# Altium schematic sheet MCU.SchDoc
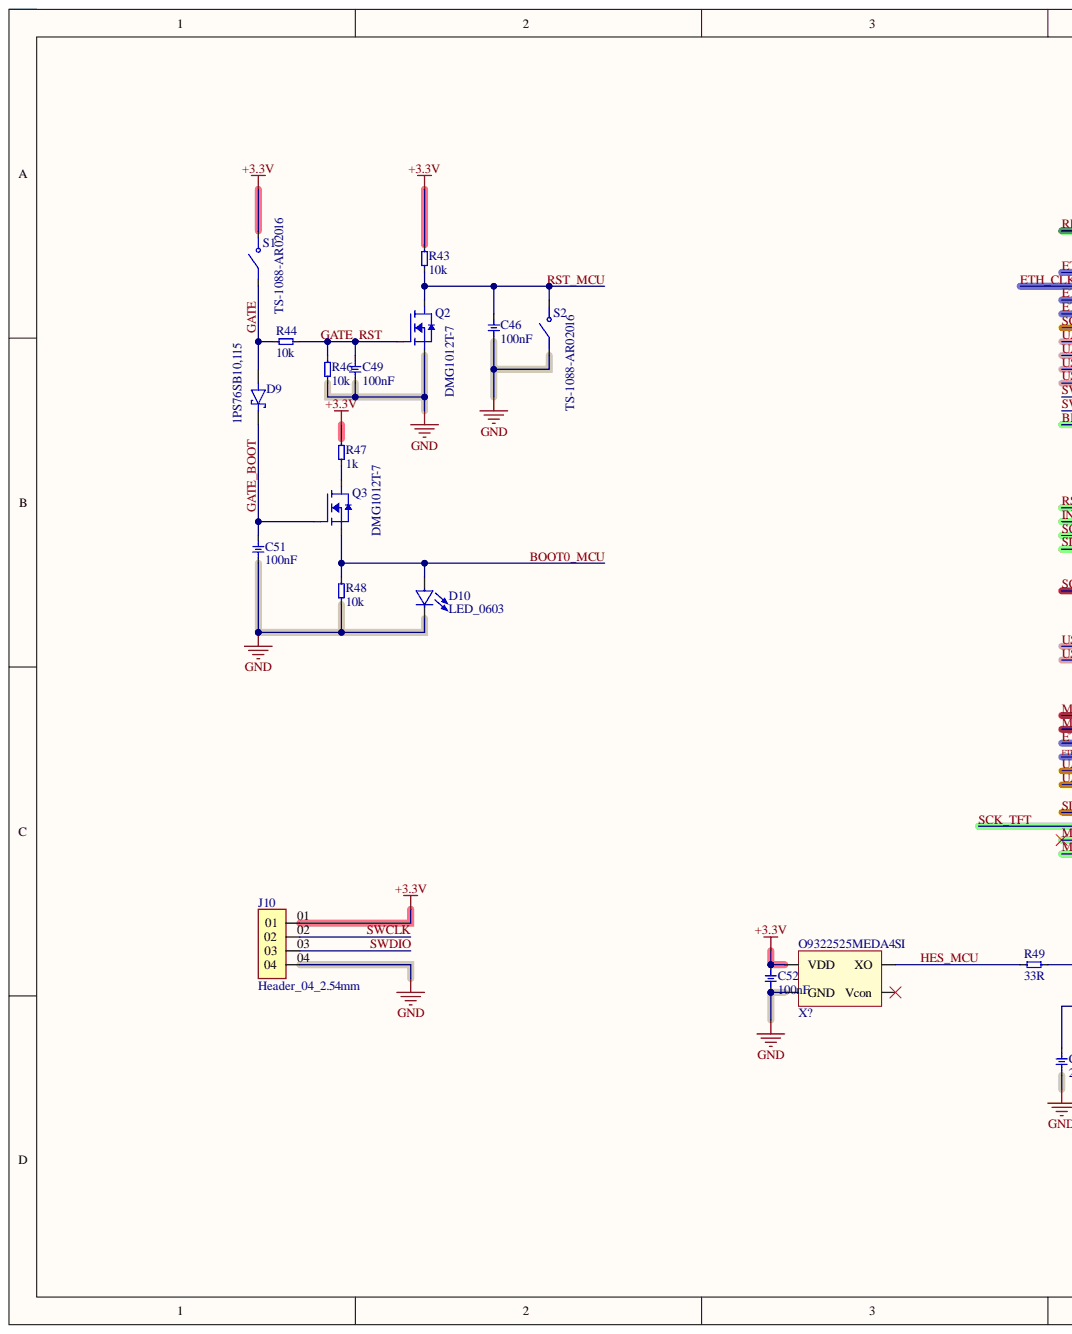
[diagram p0: MCU.SchDoc, left]
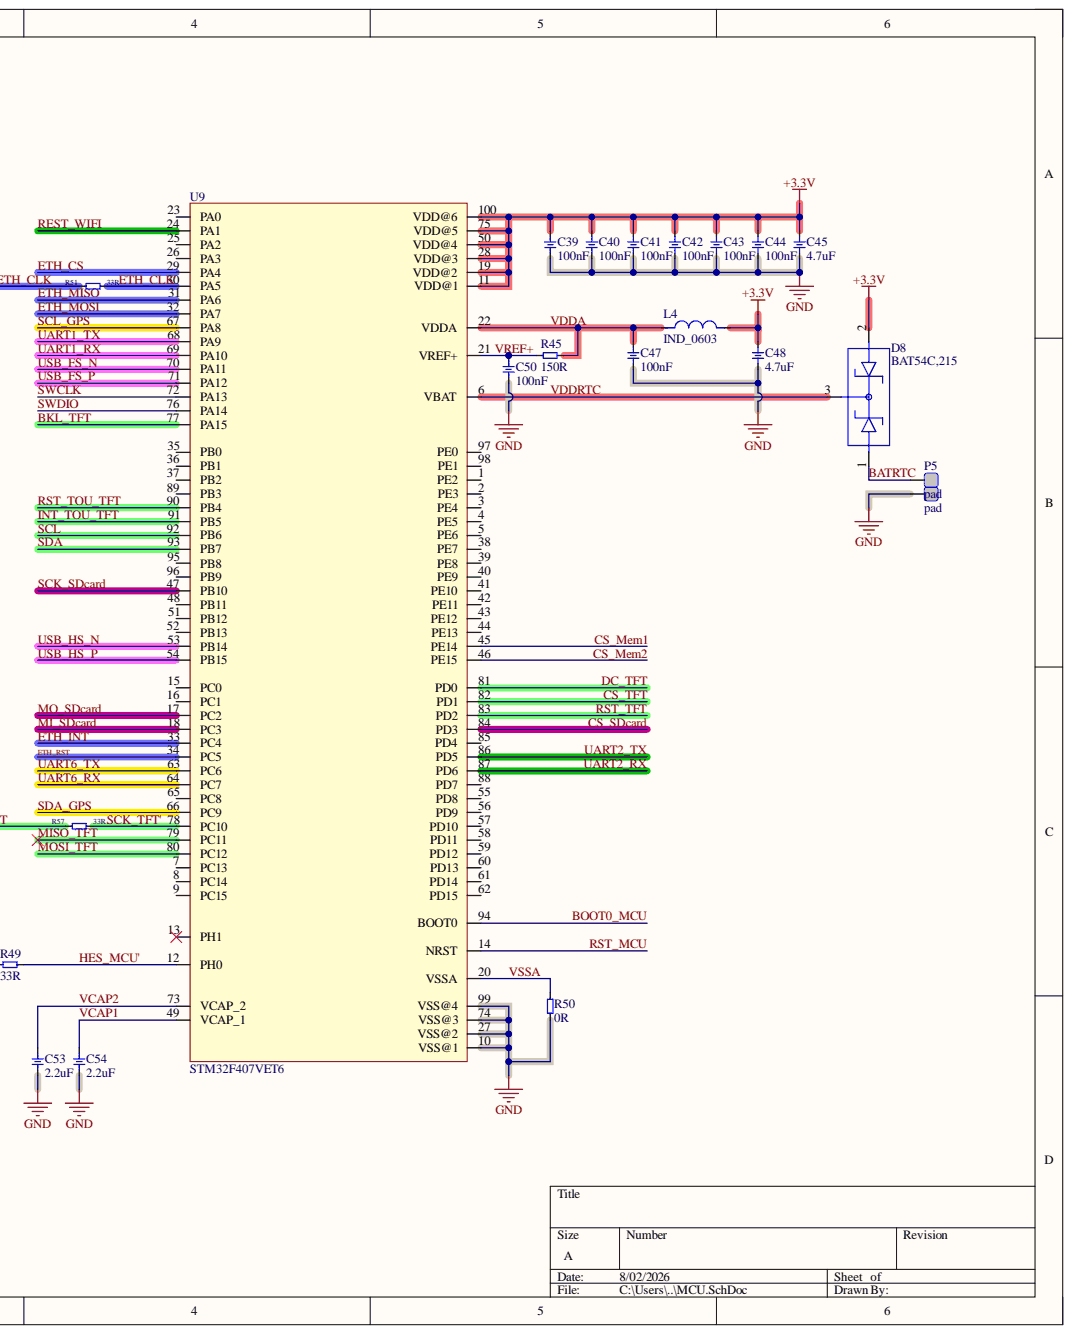
[diagram p1: MCU.SchDoc, right]

## Parts
C39  100nF  [CAP_0603]
C40  100nF  [CAP_0603]
C41  100nF  [CAP_0603]
C42  100nF  [CAP_0603]
C43  100nF  [CAP_0603]
C44  100nF  [CAP_0603]
C45  4.7uF  [CAP_0603]
C46  100nF  [CAP_0402]
C47  100nF  [CAP_0603]
C48  4.7uF  [CAP_0603]
C49  100nF  [CAP_0402]
C50  100nF  [CAP_0603]
C51  100nF  [CAP_0402]
C52  100nF  [CAP_0603]
C53  2.2uF  [CAP_0603]
C54  2.2uF  [CAP_0603]
D8  BAT54C,215  [SOT23]
D9  1PS76SB10,115  [SOD323]
D10  LED_0603  [LED_0603]
J10  Header_04_2.54mm  [SAMTEC_TSW-104-07-G-S]
L4  IND_0603  [IND_0603]
O9322525MEDA4SI  X?  [Xtai_3325_4P_Active]
P5  pad  [Pad]
P6  pad  [Pad]
Q2  DMG1012T-7  [SOT523]
Q3  DMG1012T-7  [SOT523]
R43  10k  [RES_0402]
R44  10k  [RES_0402]
R45  150R  [RES_0603]
R46  10k  [RES_0402]
R47  1k  [RES_0402]
R48  10k  [RES_0402]
R49  33R  [RES_0603]
R50  0R  [RES_0603]
R51  33R  [RES_0603]
R57  33R  [RES_0603]
S1  TS-1088-AR02016  [SW_TS-1088-AR02016]
S2  TS-1088-AR02016  [SW_TS-1088-AR02016]
U9  STM32F407VET6  [LQFP-100]

## Nets
+3.3V: C39.2 C40.2 C41.2 C42.2 C43.2 C44.2 C45.2 C48.2 C52.2 D8.2 J10.01 L4.2 O9322525MEDA4SI.4(VDD) R43.2 R47.2 S1.2 U9.11(VDD@1) U9.19(VDD@2) U9.28(VDD@3) U9.50(VDD@4) U9.75(VDD@5) U9.100(VDD@6)
GND: C39.1 C40.1 C41.1 C42.1 C43.1 C44.1 C45.1 C46.1 C47.1 C48.1 C49.1 C50.1 C51.1 C52.1 C53.1 C54.1 D10.C J10.04 O9322525MEDA4SI.2(GND) P6.2 Q2.2 R46.1 R48.1 R50.1 S2.1 U9.10(VSS@1) U9.27(VSS@2) U9.74(VSS@3) U9.99(VSS@4)
BATRTC: D8.1 P5.2
BKL_TFT: U9.77(PA15)
BOOT0_MCU: D10.A Q3.2 R48.2 U9.94(BOOT0)
CS_Mem1: U9.45(PE14)
CS_Mem2: U9.46(PE15)
CS_SDcard: U9.84(PD3)
CS_TFT: U9.82(PD1)
DC_TFT: U9.81(PD0)
ETH_CLK: R51.2
ETH_CLK': R51.1 U9.30(PA5)
ETH_CS: U9.29(PA4)
ETH_INT: U9.33(PC4)
ETH_MISO: U9.31(PA6)
ETH_MOSI: U9.32(PA7)
ETH_RST: U9.34(PC5)
GATE: D9.2 R44.2 S1.1
GATE_BOOT: C51.2 D9.1 Q3.1
GATE_RST: C49.2 Q2.1 R44.1 R46.2
HES_MCU: O9322525MEDA4SI.3(XO) R49.1
HES_MCU': R49.2 U9.12(PH0)
INT_TOU_TFT: U9.91(PB5)
MI_SDcard: U9.18(PC3)
MISO_TFT: U9.79(PC11)
MO_SDcard: U9.17(PC2)
MOSI_TFT: U9.80(PC12)
REST_WIFI: U9.24(PA1)
RST_MCU: C46.2 Q2.3 R43.1 S2.2 U9.14(NRST)
RST_TFT: U9.83(PD2)
RST_TOU_TFT: U9.90(PB4)
SCK_SDcard: U9.47(PB10)
SCK_TFT: R57.2
SCK_TFT': R57.1 U9.78(PC10)
SCL: U9.92(PB6)
SCL_GPS: U9.67(PA8)
SDA: U9.93(PB7)
SDA_GPS: U9.66(PC9)
SWCLK: J10.02 U9.72(PA13)
SWDIO: J10.03 U9.76(PA14)
UART1_RX: U9.69(PA10)
UART1_TX: U9.68(PA9)
UART2_RX: U9.87(PD6)
UART2_TX: U9.86(PD5)
UART6_RX: U9.64(PC7)
UART6_TX: U9.63(PC6)
USB_FS_N: U9.70(PA11)
USB_FS_P: U9.71(PA12)
USB_HS_N: U9.53(PB14)
USB_HS_P: U9.54(PB15)
VCAP1: C54.2 U9.49(VCAP_1)
VCAP2: C53.2 U9.73(VCAP_2)
VDDA: C47.2 L4.1 R45.1 U9.22(VDDA)
VDDRTC: D8.3 U9.6(VBAT)
VREF+: C50.2 R45.2 U9.21(VREF+)
VSSA: R50.2 U9.20(VSSA)
NetQ3_3: Q3.3 R47.1

Not connected: O9322525MEDA4SI 1(Vcon); U9 1(PE2) 2(PE3) 3(PE4) 4(PE5) 5(PE6) 7(PC13) 8(PC14) 9(PC15) 13(PH1) 15(PC0) 16(PC1) 23(PA0) 25(PA2) 26(PA3) 35(PB0) 36(PB1) 37(PB2) 38(PE7) 39(PE8) 40(PE9) 41(PE10) 42(PE11) 43(PE12) 44(PE13) 48(PB11) 51(PB12) 52(PB13) 55(PD8) 56(PD9) 57(PD10) 58(PD11) 59(PD12) 60(PD13) 61(PD14) 62(PD15) 65(PC8) 85(PD4) 88(PD7) 89(PB3) 95(PB8) 96(PB9) 97(PE0) 98(PE1)

## Library data of the parts
C39-C45 C47 C48 C50 C52-C54 = CAP_0603: Component Kind: Standard; Library Name: RQSchlib.SchLib
C46 C49 C51 = CAP_0402: Component Kind: Standard; Library Name: RQSchlib.SchLib
D8 = BAT54C,215: 30V 1 Pair Common Cathode 800mV@100mA 200mA SOT-23 Schottky Diodes ROHS; MF: Nexperia USA; Check_prices: https://www.snapeda.com/parts/BAT54C,215/Nexperia/view-part/?ref=eda; Component Kind: Standard; MP: BAT54C,215; Package: SOT23-3 Nexperia USA Inc.; SnapEDA_Link: https://www.snapeda.com/parts/BAT54C,215/Nexperia/view-part/?ref=snap; Library Name: RQSchlib.SchLib
D9 = 1PS76SB10,115: Diode Schottky 30 V 200mA (DC) Surface Mount SOD-323; MF: Nexperia USA; Check_prices: https://www.snapeda.com/parts/1PS76SB10,115/Nexperia/view-part/?ref=eda; Component Kind: Standard; MP: 1PS76SB10,115; Package: SOD323-2 Nexperia USA Inc.; SnapEDA_Link: https://www.snapeda.com/parts/1PS76SB10,115/Nexperia/view-part/?ref=snap; Library Name: RQSchlib.SchLib
D10 = LED_0603: LED SMD 0603 Ultra Yellow 593nm 70mcd Water Clear Lens 130 Deg Viewing Angle | Bivar Inc. SM0603UYC; Check_prices: https://www.snapeda.com/parts/SM0603UYC/Bivar/view-part/?ref=eda; Component Kind: Standard; MF: Bivar; MP: SM0603UYC; Package: 0603 Bivar; SnapEDA_Link: https://www.snapeda.com/parts/SM0603UYC/Bivar/view-part/?ref=snap; Library Name: RQSchlib.SchLib
J10 = Header_04_2.54mm: MF: Samtec; MP: TSW-104-07-G-S; Availability: Unavailable; Component Kind: Standard; Package: None; Price: None; Library Name: RQSchlib.SchLib
L4 = IND_0603: MF: TDK; MP: MLG1005S2N0BT000; Check_prices: https://www.snapeda.com/parts/MLG1005S2N0BT000/TDK/view-part/?ref=eda; Component Kind: Standard; Digi-Key_Part_Number: 445-16277-2-ND; Package: 1005-2 TDK; Purchase-URL: https://pricing.snapeda.com/search?q=MLG1005S2N0BT000&ref=eda; SnapEDA_Link: https://www.snapeda.com/parts/MLG1005S2N0BT000/TDK/view-part/?ref=snap; Library Name: RQSchlib.SchLib
O9322525MEDA4SI = Xtal_3325_Active: -40℃~+85℃ 25MHz 3.3V CMOS ±20ppm ±30ppm SMD3225-4P Crystal Oscillators ROHS; Component Kind: Standard; Library Name: RQSchlib.SchLib
Q2 Q3 = DMG1012T-7: 20V 630mA 280mW 1V@250uA 1 N-Channel SOT-523 MOSFETs ROHS; MF: Diotec Semiconductor; MP: 2N7002; Check_prices: https://www.snapeda.com/parts/2N7002/Diotec/view-part/?ref=eda; Component Kind: Standard; Package: SOT-23 Diotec; SnapEDA_Link: https://www.snapeda.com/parts/2N7002/Diotec/view-part/?ref=snap; Library Name: RQSchlib.SchLib
R43 R44 R46-R48 = RES_0402: Component Kind: Standard; Library Name: RQSchlib.SchLib
R45 R49-R51 R57 = RES_0603: Component Kind: Standard; Library Name: RQSchlib.SchLib
S1 S2 = TS-1088-AR02016: 50mA 4mm 100MÎ© 100,000 Times 12V 160gf 3mm 2mm Round Button Vertical welding SPST SMD Tactile Switches ROHS; MF: Xunpu; Check_prices: https://www.snapeda.com/parts/TS-1088-AR02016/Xunpu/view-part/?ref=eda; Component Kind: Standard; MP: TS-1088-AR02016; Package: Package; SnapEDA_Link: https://www.snapeda.com/parts/TS-1088-AR02016/Xunpu/view-part/?ref=snap; Library Name: RQSchlib.SchLib
U9 = STM32F407VET6: -40℃~+85℃ 1.8V~3.6V 12bit 12bit 168MHz 192KB 32 Bit 512KB 82 ARM Cortex-M4 Built-in FLASH LQFP-100(14x14) Microcontrollers (MCU/MPU/SOC) ROHS; MF: STMicroelectronics; Availability: Unavailable; Component Kind: Standard; MP: STM32F407VET6; Package: LQFP-100 STMicroelectronics; Price: None; SnapEDA_Link: https://www.snapeda.com/parts/STM32F407VET6/STMicroelectronics/view-part/45214/?ref=snap; Library Name: RQSchlib.SchLib
P5 P6 = pad: Component Kind: Standard; Library Name: RQSchlib.SchLib
